# Altium schematic sheet STM3F103RC.SchDoc(STM3F103RC)
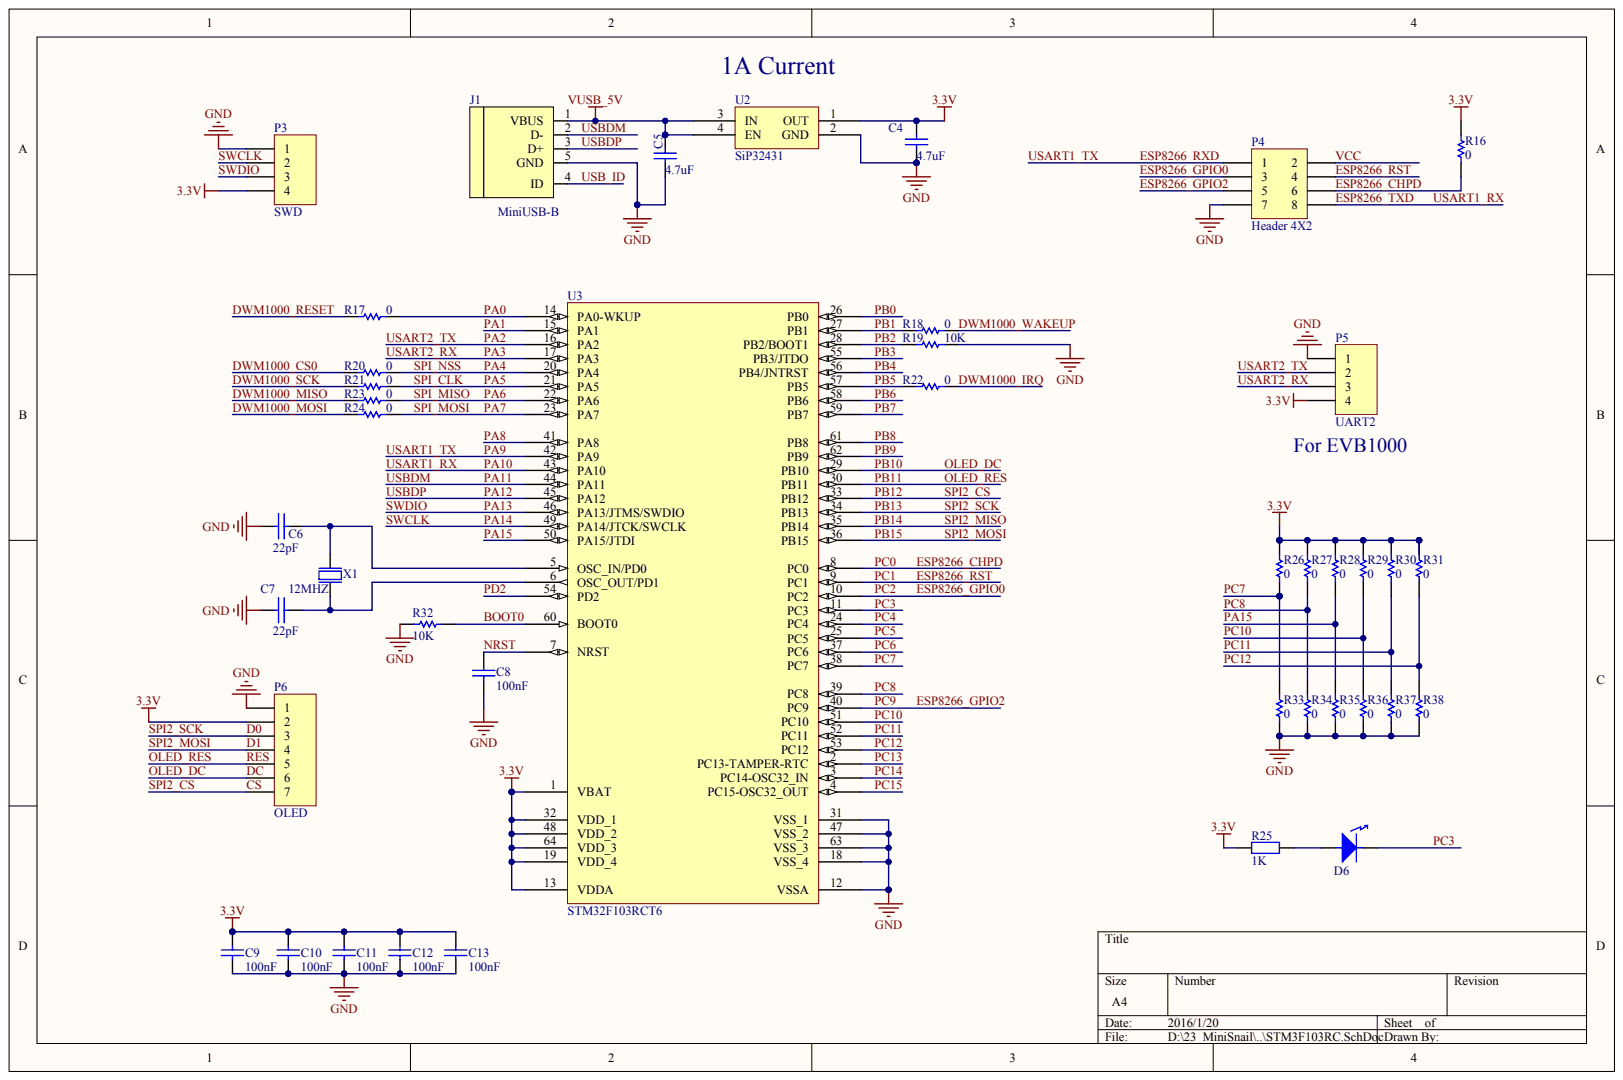
[diagram p0: STM3F103RC.SchDoc]

## Parts
C4  4.7uF
C5  4.7uF
C6  22pF
C7  22pF
C8  100nF
C9  100nF
C10  100nF
C11  100nF
C12  100nF
C13  100nF
D6  LG Q971-KN-1  (value not drawn)
J1  MiniUSB-B  [UX60SC-MB-5ST]
P3  SWD
P4  Header 4X2
P5  UART2
P6  OLED
R16  0
R17  0
R18  0
R19  10K
R20  0
R21  0
R22  0
R23  0
R24  0
R25  1K
R26  0
R27  0
R28  0
R29  0
R30  0
R31  0
R32  10K
R33  0
R34  0
R35  0
R36  0
R37  0
R38  0
U2  SiP32431
U3  STM32F103RCT6
X1  12MHZ  [BT-XTAL_2520]

## Nets
3.3V: C4.1 C9.1 C10.1 C11.1 C12.1 C13.1 P3.4 P5.4 P6.2 R16.2 R25.2 R26.2 R27.2 R28.2 R29.2 R30.2 R31.2 U2.1(OUT) U3.1(VBAT) U3.13(VDDA) U3.19(VDD_4) U3.32(VDD_1) U3.48(VDD_2) U3.64(VDD_3)
GND: C4.2 C5.2 C6.2 C7.2 C8.1 C9.2 C10.2 C11.2 C12.2 C13.2 J1.5(GND) P3.1 P4.7 P5.1 P6.1 R19.2 R32.1 R33.1 R34.1 R35.1 R36.1 R37.1 R38.1 U2.2(GND) U3.12(VSSA) U3.18(VSS_4) U3.31(VSS_1) U3.47(VSS_2) U3.63(VSS_3)
VUSB_5V: C5.1 J1.1(VBUS) U2.3(IN) U2.4(EN)
BOOT0: R32.2 U3.60(BOOT0)
CS: P6.7 U3.33(PB12)
D0: P6.3 U3.34(PB13)
D1: P6.4 U3.36(PB15)
DC: P6.6 U3.29(PB10)
DWM1000_CS0: R20.1
DWM1000_IRQ: R22.2
DWM1000_MISO: R23.1
DWM1000_MOSI: R24.1
DWM1000_RESET: R17.1
DWM1000_SCK: R21.1
DWM1000_WAKEUP: R18.2
ESP8266_CHPD: P4.6 R16.1 U3.8(PC0)
ESP8266_GPIO0: P4.3 U3.10(PC2)
ESP8266_GPIO2: P4.5 U3.40(PC9)
ESP8266_RST: P4.4 U3.9(PC1)
ESP8266_RXD: P4.1 U3.42(PA9)
ESP8266_TXD: P4.8 U3.43(PA10)
NRST: C8.2 U3.7(NRST)
OLED_RES: P6.5 U3.30(PB11)
PA0: R17.2 U3.14(PA0-WKUP)
PA1: U3.15(PA1)
PA2: P5.2 U3.16(PA2)
PA3: P5.3 U3.17(PA3)
PA4: R20.2 U3.20(PA4)
PA5: R21.2 U3.21(PA5)
PA6: R23.2 U3.22(PA6)
PA7: R24.2 U3.23(PA7)
PA8: U3.41(PA8)
PA11: J1.2(D-) U3.44(PA11)
PA12: J1.3(D+) U3.45(PA12)
PA13: P3.3 U3.46(PA13/JTMS/SWDIO)
PA14: P3.2 U3.49(PA14/JTCK/SWCLK)
PA15: R28.1 R35.2 U3.50(PA15/JTDI)
PB0: U3.26(PB0)
PB1: R18.1 U3.27(PB1)
PB2: R19.1 U3.28(PB2/BOOT1)
PB3: U3.55(PB3/JTDO)
PB4: U3.56(PB4/JNTRST)
PB5: R22.1 U3.57(PB5)
PB6: U3.58(PB6)
PB7: U3.59(PB7)
PB8: U3.61(PB8)
PB9: U3.62(PB9)
PB14: U3.35(PB14)
PC3: D6.2 U3.11(PC3)
PC4: U3.24(PC4)
PC5: U3.25(PC5)
PC6: U3.37(PC6)
PC7: R26.1 R33.2 U3.38(PC7)
PC8: R27.1 R34.2 U3.39(PC8)
PC10: R29.1 R36.2 U3.51(PC10)
PC11: R30.1 R37.2 U3.52(PC11)
PC12: R31.1 R38.2 U3.53(PC12)
PC13: U3.2(PC13-TAMPER-RTC)
PC14: U3.3(PC14-OSC32_IN)
PC15: U3.4(PC15-OSC32_OUT)
PD2: U3.54(PD2)
USB_ID: J1.4(ID)
VCC: P4.2
NetC6_1: C6.1 U3.5(OSC_IN/PD0) X1.1
NetC7_1: C7.1 U3.6(OSC_OUT/PD1) X1.2
NetD6_1: D6.1 R25.1

## Library data of the parts
C4 C5 C9-C13 = (no symbol name): Value: 10nF; Published: 8-Jun-2000; Revision: July-2002: Re-released for DXP Platform.; Publisher: Altium Limited
C8 = (no symbol name): Value: 100pF; Published: 8-Jun-2000; Revision: July-2002: Re-released for DXP Platform.; Publisher: Altium Limited
D6 = (no symbol name): Manufacturer: OSRAM Opto Semiconductors Inc; Color: Green; Wavelength - Dominant: 570nm; Wavelength - Peak: 572nm; Millicandela Rating: 10mcd; Voltage - Forward (Vf) Typ: 2.2V; Current - Test: 20mA; Lens Type: Diffused; Lens Style/Size: Rectangle with Flat Top, 1mm x 0.8mm; Supplier 1: Digi-Key; Manufacturer Part Number: LG Q971-KN-1; RoHS: RoHS Compliant; Category: Optoelectronics; Stock 1: 44000; Pricing 1: 4000=0.03632 (USD); Packaging: Tape & Reel (TR); : 160°|NAME=Viewing Angle; Package / Case: 0603 (1608 Metric); Size / Dimension: 1.60mm L x 0.80mm W; Height: 0.80mm; Mounting Type: Surface Mount; Luminous Flux @ Current - Test: -; ComponentLink1URL: http://catalog.osram-os.com/catalogue/catalogue.do;jsessionid=DE4D071D0D2758CC117C711BF848053E?act=downloadFile&favOid=0200000600005069000100b6; ComponentLink1Description: http://catalog.osram-os.com/catalogue/catalogue.do;jsessionid=DE4D071D0D2758CC117C711BF848053E?act=downloadFile&favOid=0200000600005069000100b6
J1 = (no symbol name): Part Number: Hirose UX60SC-MB-5ST; Manufacturer: Hirose; Manufacturer Part Number: UX60SC-MB-5ST(80); 2nd source part number: 798-UX60SCMB5ST01; Artikkelnr Noca: 55029014; Value: MiniUSB-B; Datasheet: http://www.hirose.co.jp/cataloge_hp/e24000153.pdf; LatestRevisionDate: 2012-06-19; Published: 2012-06-19; Note: *; Supplier: Digi-Key; Supplier part number: H11671CT-ND; 2nd source Supplier: Mouser; Publisher: Nordic Semiconductor
R16-R24 R26-R38 = (no symbol name): Value: 1K; Published: 8-Jun-2000; Revision: July-2002: Re-released for DXP Platform.; Publisher: Altium Limited
X1 = (no symbol name): Part Number: NX2520SA-16MHZ-STD-CSW-5; Manufacturer: NDK; Value: 16MHz; Note: XTAL SMD 16.000MHz; Artikkelnr Noca: 22019192; Datasheet: http://www.ndk.com/images/products/catalog/c_NX2520SA-STD-CSW-5_e.pdf; LatestRevisionDate: 2012-06-15; Pin Count: 4; Published: 2012-06-15; Supplier: Digi-Key; Supplier part number: 644-1169-1-ND; 2nd source Supplier: *; 2nd source part number: *; Publisher: Nordic Semiconductor
C6 C7 P3-P6 R25 U2 U3 = (no symbol name)
  P3-P6: LatestRevisionDate: 17-Jul-2002; LatestRevisionNote: Re-released for DXP Platform.
  R25: Published: 8-Jun-2000; LatestRevisionDate: 17-Jul-2002; LatestRevisionNote: Re-released for DXP Platform.; PackageReference: AXIAL-0.4; PackageDescription: Axial Device, Thru-Hole; 2 Leads; 0.4 in Pin Spacing; Value: 1K
  U3: Published: *; DatasheetVersion: *; PackageVersion: *; PackageReference: *; PackageDescription: *; ComponentLink1Description: *; ComponentLink1URL: *; ComponentLink2Description: *; ComponentLink2URL: *; Publisher: *
  P3-P6 R25: Publisher: Altium Limited
